# Altium schematic sheet Power.SchDoc
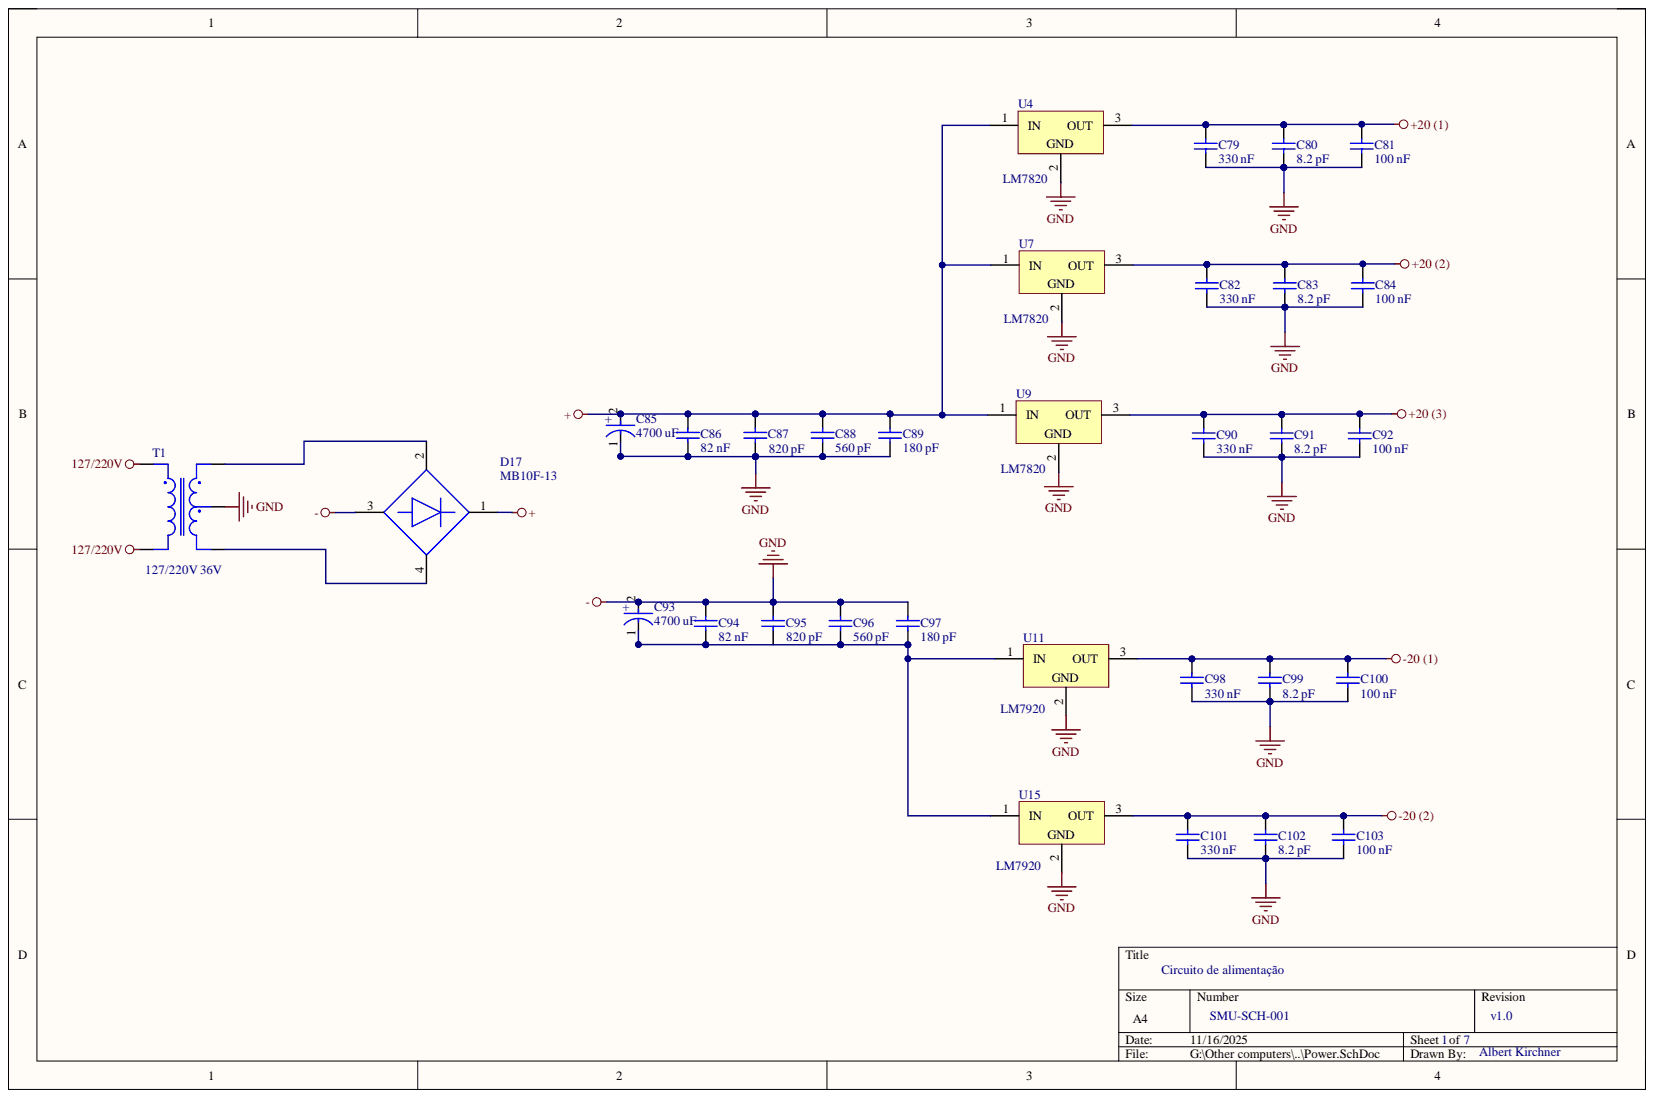
[diagram p0: Power.SchDoc]

## Parts
C79  330 nF  [CAPC2013X70X45ML10T25]
C80  8.2 pF  [CAPC2013X70X45ML10T25]
C81  100 nF  [CAPC2013X70X45ML10T25]
C82  330 nF  [CAPC2013X70X45ML10T25]
C83  8.2 pF  [CAPC2013X70X45ML10T25]
C84  100 nF  [CAPC2013X70X45ML10T25]
C85  4700 uF  [FP-RAD-TH-D_10_0_5-L_16_1-MFG]
C86  82 nF  [CAPC2013X70X45ML10T25]
C87  820 pF  [CAPC2013X70X45ML10T25]
C88  560 pF  [CAPC2013X70X45ML10T25]
C89  180 pF  [CAPC2013X70X45ML10T25]
C90  330 nF  [CAPC2013X70X45ML10T25]
C91  8.2 pF  [CAPC2013X70X45ML10T25]
C92  100 nF  [CAPC2013X70X45ML10T25]
C93  4700 uF  [FP-RAD-TH-D_10_0_5-L_16_1-MFG]
C94  82 nF  [CAPC2013X70X45ML10T25]
C95  820 pF  [CAPC2013X70X45ML10T25]
C96  560 pF  [CAPC2013X70X45ML10T25]
C97  180 pF  [CAPC2013X70X45ML10T25]
C98  330 nF  [CAPC2013X70X45ML10T25]
C99  8.2 pF  [CAPC2013X70X45ML10T25]
C100  100 nF  [CAPC2013X70X45ML10T25]
C101  330 nF  [CAPC2013X70X45ML10T25]
C102  8.2 pF  [CAPC2013X70X45ML10T25]
C103  100 nF  [CAPC2013X70X45ML10T25]
D17  MB10F-13  [FP-MBF-MFG]
T1  L3: 127/220V 36V  (hidden comment: Ideal Transformer With Central Tap)
U4  LM7820  [TO220]
U7  LM7820  [TO220]
U9  LM7820  [TO220]
U11  LM7920  [TO220]
U15  LM7920  [TO220]

## Nets
127/220V: T1.1 T1.2
+: C85.2 C86.2 C87.2 C88.2 C89.2 D17.1 U4.1 U7.1 U9.1
+20 (1): C79.2 C80.2 C81.2 U4.3
+20 (2): C82.2 C83.2 C84.2 U7.3
+20 (3): C90.2 C91.2 C92.2 U9.3
-: C79.1 C80.1 C81.1 C82.1 C83.1 C84.1 C85.1 C86.1 C87.1 C88.1 C89.1 C90.1 C91.1 C92.1 C93.2 C94.2 C95.2 C96.2 C97.2 C98.1 C99.1 C100.1 C101.1 C102.1 C103.1 D17.3 T1.4 U4.2 U7.2 U9.2 U11.2 U15.2
-20 (1): C98.2 C99.2 C100.2 U11.3
-20 (2): C101.2 C102.2 C103.2 U15.3
NetC93_1: C93.1 C94.1 C95.1
NetC96_1: C96.1 C97.1 U11.1 U15.1
NetD17_2: D17.2 T1.3
NetD17_4: D17.4 T1.5

## Library data of the parts
C79-C84 C86-C92 C94-C103 = CMP-2007-00997-1: Manufacturer: Murata; Part Number: GRM216R71H472KA01D; Component Kind: Standard; Library Name: Altium Content Vault
C85 C93 = CMP-05427-000698-1: Aluminum Electrolytic Capacitors (Radial Lead Type) AEC-Q200 Qualified 330uF ±20% 50V; Lead Diameter: 0.6mm; Lead/Base Style: Straight; Life (Hours): 2000Hour; Ripple Current: 410mA; Capacitance: 330uF; Case/Package: Radial; Component Kind: Standard; Construction: RADIAL; Contact Plating: Tin; Diameter: 10mm; Height: 16mm; Lead Pitch: 5mm; Length: 16mm; Library Name: Altium Content Vault; Max Operating Temperature: 105°C; Min Operating Temperature: -55°C; Mount: Through Hole; Package Quantity: 500; Packaging: Ammo Pack; Radiation Hardening: No; RoHS Compliant: Yes; Series: NHG; Termination: Radial; Tolerance: 20%; Voltage Rating (DC): 50V
D17 = CMP-12791-000022-1: Bridge Rectifier Single Phase Standard 1kV Surface Mount MBF; Manufacturer: Diodes Incorporated; Capacitance: 8pF; Forward Current: 0.5A; Max Junction Temp: +150°C; Max Repetitive Reverse Voltage: 1000V; Max Surge Forward Current: 30A; Peak Reverse Current: 0.2uA; Value: 8pF; Automotive: No; Category: Diode; Component Kind: Standard; ComponentLink2Description: Datasheet; ComponentLink2URL: https://www.diodes.com/assets/Datasheets/MB10F.pdf; Device Class L1: Discrete Semiconductors; Device Class L2: Diodes; Device Class L3: Rectifier Diodes; Digikey Description: BRIDGE RECT 1PHASE 1KV 800MA MBF; Footprint Url: https://www.diodes.com/assets/Package-Files/MBF.pdf; Height: 1.8mm; Lead Free: Yes; Library Name: Altium Content Vault; Manufacturer Part Number: MB10F-13; Mouser Description: Diode Rectifier Bridge Single 1000V 0.8A 4-Pin Case MBF T/R; Octopart: https://octopart.com/mb10f-13-diodes+inc.-82160020; Package: MBF; Reverse Voltage: 1000V; RoHS: TRUE; Temperature: -55°C to +150°C; Temperature Range High: +150°C; Temperature Range Low: -55°C
T1 = Transformer With Central Tap Ideal: SimulationGenericComponent: True; Component Kind: Standard; Description: Ideal Transformer With Central Tap; Library Name: Simulation Generic Components.IntLib; Simulation: IDEAL_CENTAP
U4 U7 U9 U11 U15 = CMP-0244-00484-1: Positive Voltage Regulator, 5V, 3-Pin TO-220; Component Kind: Standard; ComponentLink1Description: Manufacturer URL; ComponentLink1URL: http://www.st.com; ComponentLink2Description: Datasheet; ComponentLink2URL: http://www.st.com/internet/com/TECHNICAL_RESOURCES/TECHNICAL_LITERATURE/DATASHEET/CD00000444.pdf; ComponentLink3Description: Package Specification; ComponentLink3URL: http://www.st.com/internet/com/TECHNICAL_RESOURCES/TECHNICAL_LITERATURE/PACKAGE_INFORMATION/CD00004649.pdf; DatasheetVersion: 16/11/2011; Library Name: Altium Content Vault; PackageDescription: TO, Thru-Hole, Vertical, Heatsink Mounted; 3 In-Line Leads; Pitch 2.54 mm; PackageReference: TO220; PackageVersion: ver3.0
